# Altium schematic sheet Power_IO.SchDoc(Power_IO)
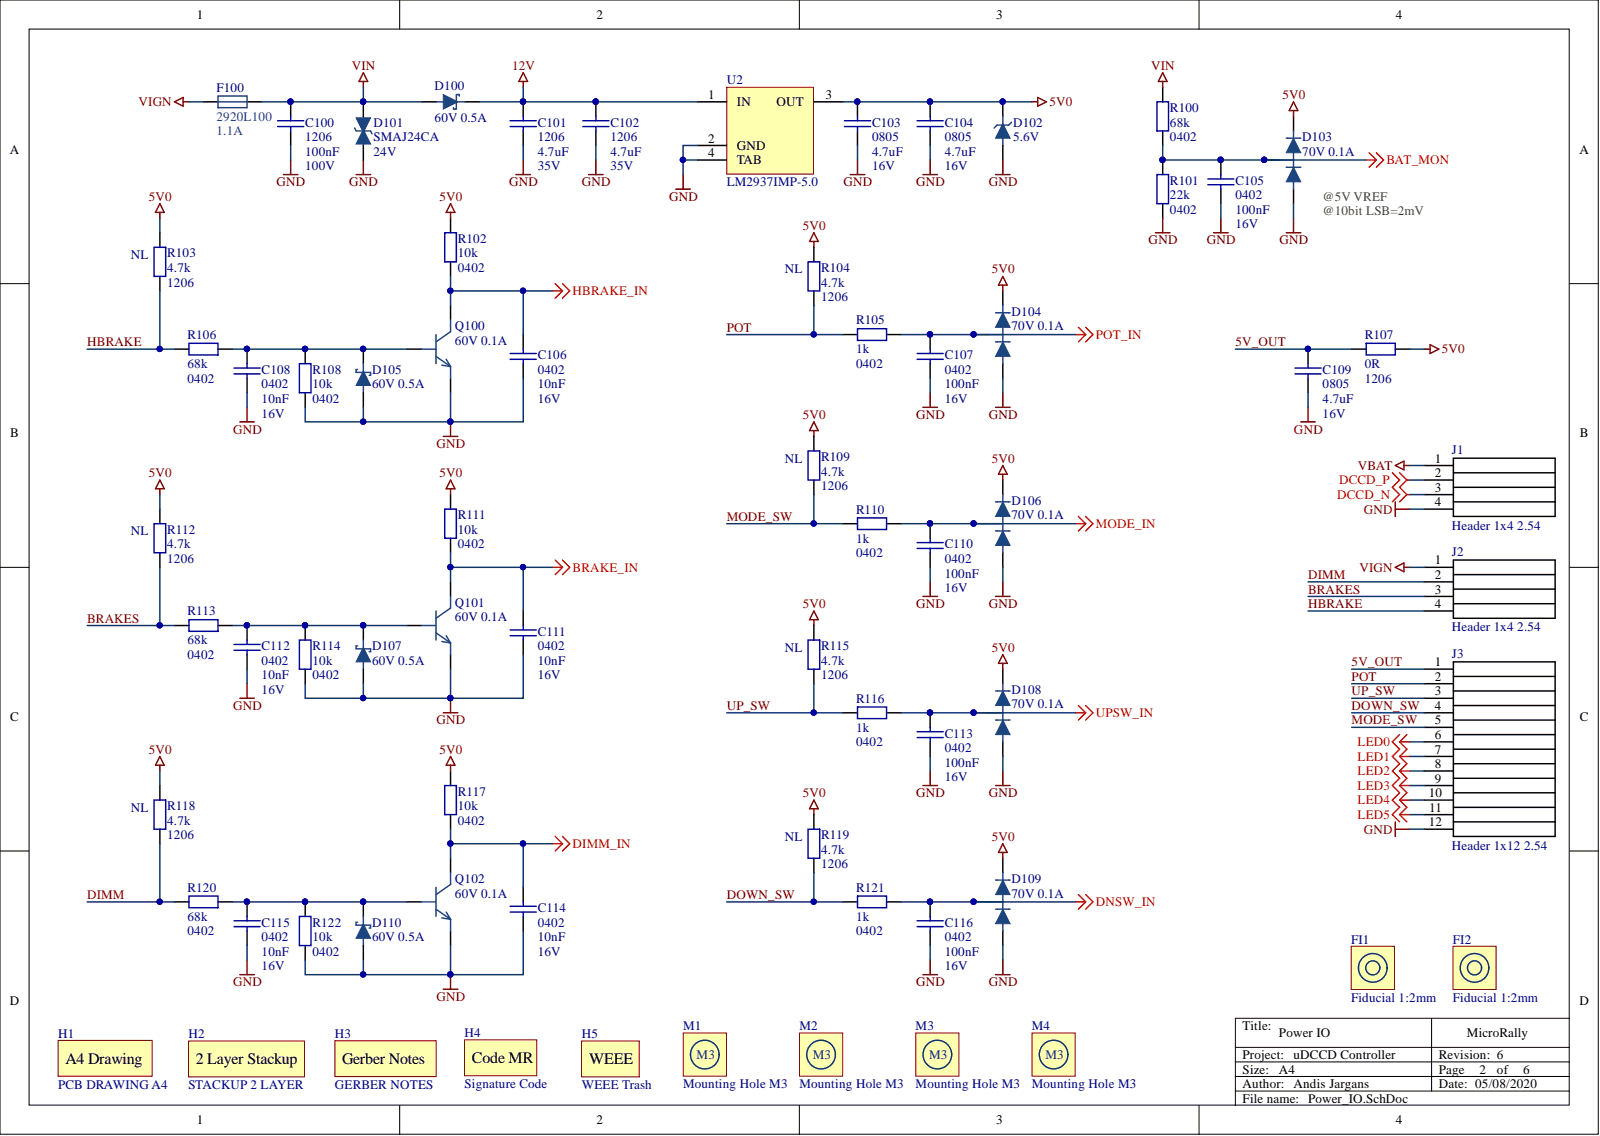
[diagram p0: Power_IO.SchDoc]

## Parts
C100  100n  value 100nF  PACKAGE: 1206  _VOLTAGE: 100V  [C 1206]
C101  4.7u  value 4.7uF  PACKAGE: 1206  _VOLTAGE: 35V  [C 1206]
C102  4.7u  value 4.7uF  PACKAGE: 1206  _VOLTAGE: 35V  [C 1206]
C103  4.7u  value 4.7uF  PACKAGE: 0805  _VOLTAGE: 16V  [C 0805]
C104  4.7u  value 4.7uF  PACKAGE: 0805  _VOLTAGE: 16V  [C 0805]
C105  100n  value 100nF  PACKAGE: 0402  _VOLTAGE: 16V  [C 0402]
C106  10n  value 10nF  PACKAGE: 0402  _VOLTAGE: 16V  [C 0402]
C107  100n  value 100nF  PACKAGE: 0402  _VOLTAGE: 16V  [C 0402]
C108  10n  value 10nF  PACKAGE: 0402  _VOLTAGE: 16V  [C 0402]
C109  4.7u  value 4.7uF  PACKAGE: 0805  _VOLTAGE: 16V  [C 0805]
C110  100n  value 100nF  PACKAGE: 0402  _VOLTAGE: 16V  [C 0402]
C111  10n  value 10nF  PACKAGE: 0402  _VOLTAGE: 16V  [C 0402]
C112  10n  value 10nF  PACKAGE: 0402  _VOLTAGE: 16V  [C 0402]
C113  100n  value 100nF  PACKAGE: 0402  _VOLTAGE: 16V  [C 0402]
C114  10n  value 10nF  PACKAGE: 0402  _VOLTAGE: 16V  [C 0402]
C115  10n  value 10nF  PACKAGE: 0402  _VOLTAGE: 16V  [C 0402]
C116  100n  value 100nF  PACKAGE: 0402  _VOLTAGE: 16V  [C 0402]
D100  value 60V 0.5A  _VOLTAGE: 60V  _IF: 0.5A  [SOD-123]  (hidden comment: STPS0560Z)
D101  SMAJ24CA  value 24V  [DO-214AC]
D102  value 5.6V  [SOT23-3]  (hidden comment: BZX84C5V6)
D103  value 70V 0.1A  _VOLTAGE: 70V  [SOT23-3]  (hidden comment: SBAS70-04LT1G)
D104  value 70V 0.1A  _VOLTAGE: 70V  [SOT23-3]  (hidden comment: SBAS70-04LT1G)
D105  value 60V 0.5A  _VOLTAGE: 60V  [SOD-123]  (hidden comment: STPS0560Z)
D106  value 70V 0.1A  _VOLTAGE: 70V  [SOT23-3]  (hidden comment: SBAS70-04LT1G)
D107  value 60V 0.5A  _VOLTAGE: 60V  [SOD-123]  (hidden comment: STPS0560Z)
D108  value 70V 0.1A  _VOLTAGE: 70V  [SOT23-3]  (hidden comment: SBAS70-04LT1G)
D109  value 70V 0.1A  _VOLTAGE: 70V  [SOT23-3]  (hidden comment: SBAS70-04LT1G)
D110  value 60V 0.5A  _VOLTAGE: 60V  [SOD-123]  (hidden comment: STPS0560Z)
F100  2920L100  value 1.1A  [FL-2920L]
FI1  Fiducial 1:2mm  [FIDUCAIL 1/2]  (no BOM)
FI2  Fiducial 1:2mm  [FIDUCAIL 1/2]  (no BOM)
H1  PCB DRAWING A4  [SHEET A4 DIM]  (no BOM)
H2  STACKUP 2 LAYER  [STACKUP 2 Layer]  (no BOM)
H3  GERBER NOTES  [GERBER NOTES]  (no BOM)
H4  Signature Code  [CODE MR]  (no BOM)
H5  WEEE Trash  [WEEE]  (no BOM)
J1  Header  value Header 1x4 2.54  [HEADER 2.54 1x4 V]
J2  Header  value Header 1x4 2.54  [HEADER 2.54 1x4 V]
J3  Header  value Header 1x12 2.54  [HEADER 2.54 1x12 V]
M1  Mounting Hole M3  [MOUNTING M3]  (no BOM)
M2  Mounting Hole M3  [MOUNTING M3]  (no BOM)
M3  Mounting Hole M3  [MOUNTING M3]  (no BOM)
M4  Mounting Hole M3  [MOUNTING M3]  (no BOM)
Q100  value 60V 0.1A  _Vce: 60V  [SOT23-3]  (hidden comment: MMBT2484)
Q101  value 60V 0.1A  _Vce: 60V  [SOT23-3]  (hidden comment: MMBT2484)
Q102  value 60V 0.1A  _Vce: 60V  [SOT23-3]  (hidden comment: MMBT2484)
R100  68k  PACKAGE: 0402  [R 0402]
R101  22k  PACKAGE: 0402  [R 0402]
R102  10k  PACKAGE: 0402  [R 0402]
R103  4.7k  PLACE: NL  PACKAGE: 1206  [R 1206]
R104  4.7k  PLACE: NL  PACKAGE: 1206  [R 1206]
R105  1k  PACKAGE: 0402  [R 0402]
R106  68k  PACKAGE: 0402  [R 0402]
R107  0R  PACKAGE: 1206  [R 1206]
R108  10k  PACKAGE: 0402  [R 0402]
R109  4.7k  PLACE: NL  PACKAGE: 1206  [R 1206]
R110  1k  PACKAGE: 0402  [R 0402]
R111  10k  PACKAGE: 0402  [R 0402]
R112  4.7k  PLACE: NL  PACKAGE: 1206  [R 1206]
R113  68k  PACKAGE: 0402  [R 0402]
R114  10k  PACKAGE: 0402  [R 0402]
R115  4.7k  PLACE: NL  PACKAGE: 1206  [R 1206]
R116  1k  PACKAGE: 0402  [R 0402]
R117  10k  PACKAGE: 0402  [R 0402]
R118  4.7k  PLACE: NL  PACKAGE: 1206  [R 1206]
R119  4.7k  PLACE: NL  PACKAGE: 1206  [R 1206]
R120  68k  PACKAGE: 0402  [R 0402]
R121  1k  PACKAGE: 0402  [R 0402]
R122  10k  PACKAGE: 0402  [R 0402]
U2  LM2937  value LM2937IMP-5.0  [SOT223-3]

## Nets
5V0: C103.1 C104.1 D102.3 D103.2 D104.2 D106.2 D108.2 D109.2 R102.2 R103.1 R104.1 R107.2 R109.1 R111.2 R112.1 R115.1 R117.2 R118.1 R119.1 U2.3(OUT)
12V: C101.1 C102.1 D100.1 U2.1(IN)
BAT_MON: C105.1 D103.3 R100.1 R101.2
BRAKE_IN: C111.1 Q101.3 R111.1
DCCD_N: J1.3
DCCD_P: J1.2
DIMM_IN: C114.1 Q102.3 R117.1
DNSW_IN: C116.1 D109.3 R121.2
GND: C100.2 C101.2 C102.2 C103.2 C104.2 C105.2 C106.2 C107.2 C108.2 C109.2 C110.2 C111.2 C112.2 C113.2 C114.2 C115.2 C116.2 D101.2 D102.1 D103.1 D104.1 D105.2 D106.1 D107.2 D108.1 D109.1 D110.2 J1.4 J3.12 Q100.2 Q101.2 Q102.2 R101.1 R108.1 R114.1 R122.1 U2.2(GND) U2.4(TAB)
HBRAKE_IN: C106.1 Q100.3 R102.1
LED0: J3.6
LED1: J3.7
LED2: J3.8
LED3: J3.9
LED4: J3.10
LED5: J3.11
MODE_IN: C110.1 D106.3 R110.2
POT_IN: C107.1 D104.3 R105.2
UPSW_IN: C113.1 D108.3 R116.2
VBAT: J1.1
VIGN: F100.1 J2.1
VIN: C100.1 D100.2 D101.1 F100.2 R100.2
5V_OUT: C109.1 J3.1 R107.1
BRAKES: J2.3 R112.2 R113.2
DIMM: J2.2 R118.2 R120.2
DOWN_SW: J3.4 R119.2 R121.1
HBRAKE: J2.4 R103.2 R106.2
MODE_SW: J3.5 R109.2 R110.1
POT: J3.2 R104.2 R105.1
UP_SW: J3.3 R115.2 R116.1
NetC108_1: C108.1 D105.1 Q100.1 R106.1 R108.2
NetC112_1: C112.1 D107.1 Q101.1 R113.1 R114.2
NetC115_1: C115.1 D110.1 Q102.1 R120.1 R122.2

## Library data of the parts
C100 = C_100_100V_1206: Capacitor 1206 100nF 100V; _TOLERANCE: 10%; Library Name: Capacitors Ceramic.IntLib; Component Kind: Standard; _CAPACITANCE: 100nF
C101 C102 = C_4700_35V_1206: Capacitor 1206 4.7uF 35V; _TOLERANCE: 10%; Library Name: Capacitors Ceramic.IntLib; Component Kind: Standard; _CAPACITANCE: 4.7uF
C103 C104 C109 = C_4700_16V_0805: Capacitor 0805 4.7uF 16V; _TOLERANCE: 10%; Library Name: Capacitors Ceramic.IntLib; Component Kind: Standard; _CAPACITANCE: 4.7uF
C105 C107 C110 C113 C116 = C_100_16V_0402: Capacitor 0402 100nF 16V; _TOLERANCE: 10%; Library Name: Capacitors Ceramic.IntLib; Component Kind: Standard; _CAPACITANCE: 100nF
C106 C108 C111 C112 C114 C115 = C_10_16V_0402: Capacitor 0402 10nF 16V; _TOLERANCE: 10%; Library Name: Capacitors Ceramic.IntLib; Component Kind: Standard; _CAPACITANCE: 10nF
D100 = D SC 60V 0.5A SOD123: Schottky Rectifier, 60 V, 0.5 A, Single, SOD-123; PART#: STPS0560Z; PACKAGE: SOD123; Library Name: Diodes.IntLib; Component Kind: Standard
D101 = TVS BD 24V 400W: TVS Bi-Directional Diode 24V 400W DO-214AC; _Vbr_max: 29.5V; _Vbr_,min: 26.7V; _TYPE: OVP; PART#: SMAJ24CA; PACKAGE: DO-214AC; Library Name: Circuit_protection.IntLib; Component Kind: Standard; _Vr: 24V; _POWER: 400W
D102 = D ZE 5.6V SOT-23: Zener Diode, 5.6V @5mA, SOT-23; _POWER: 250mW; PART#: BZX84C5V6; PACKAGE: SOT-23; Library Name: Diodes.IntLib; Component Kind: Standard; _VOLTAGE: 5.6V
D103 D104 D106 D108 D109 = D DS 70V 0.1A SOT23: Dual Series diode SOT23 70V 100mA; _POWER: 225mW; _IF: 0.1A; PART#: SBAS70-04LT1G; PACKAGE: SOT23-3; Library Name: Diodes.IntLib; Component Kind: Standard
D105 D107 D110 = D SC 60V 0.5A SOD123: Schottky Rectifier, 60 V, 0.5 A, Single, SOD-123; _IF: 0.5A; PART#: STPS0560Z; PACKAGE: SOD123; Library Name: Diodes.IntLib; Component Kind: Standard
F100 = PTC 1.1A 33V: Littelfuse PTC SMD Fuse 1.1A 33V 2920L100; _TYPE: OCP; _TRIP_CURRENT: 2.2A; _POWER: 1.5W; PART#: 2920L100; PACKAGE: LF-2920L; Library Name: Circuit_protection.IntLib; Component Kind: Standard; _VOLTAGE: 33V; _HOLD CURRENT: 1.1A
FI1 FI2 = FIDUCIAL 1/2: Round fiducial for Pannels d=1mm, D=2mm; Library Name: PCB_Formating.IntLib
H4 = CODE MR: MicroRally Signature Code; Library Name: PCB_Formating.IntLib
J1 J2 = Header 2.54 1x4 V Male: Pin Header Vertical Male 1x4 pins 2.54mm pitch; _CURRENT: 4A; PACKAGE: 0.1"; Library Name: Connectors.IntLib; Component Kind: Standard
J3 = Header 2.54 1x12 V Male: Pin Header Vertical Male 1x12 pins 2.54mm pitch; _CURRENT: 4A; PACKAGE: 0.1"; Library Name: Connectors.IntLib; Component Kind: Standard
M1-M4 = MH M3: Tooling hole M3, 3.2mm; Library Name: Mechanical.IntLib
Q100-Q102 = Q NPN 60V 0.1A: NPN Transistor 60V 0.1A SOT23; _TYPE: BJT NPN; _POWER: 350mW; _hFE: 100-250; PART#: MMBT2484; PACKAGE: SOT23-3; Library Name: Transistors.IntLib; Component Kind: Standard; _Vcb: 60V; _CURRENT: 0.1A
R100 R106 R113 R120 = R_68000_0402: Resistor 0402 68k 1/16W; _TOLERANCE: 1%; _POWER: 62.5mW; VALUE: 68k; Library Name: Resistors Chip.IntLib; Component Kind: Standard
R101 = R_22000_0402: Resistor 0402 22k 1/16W; _TOLERANCE: 1%; _POWER: 62.5mW; VALUE: 22k; Library Name: Resistors Chip.IntLib; Component Kind: Standard
R102 R108 R111 R114 R117 R122 = R_10000_0402: Resistor 0402 10k 1/16W; _TOLERANCE: 1%; _POWER: 62.5mW; VALUE: 10k; Library Name: Resistors Chip.IntLib; Component Kind: Standard
R103 R104 R109 R112 R115 R118 R119 = R_4700_1206: Resistor 1206 4.7k 1/4W; _TOLERANCE: 1%; _POWER: 250mW; VALUE: 4.7k; Library Name: Resistors Chip.IntLib; Component Kind: Standard
R105 R110 R116 R121 = R_1000_0402: Resistor 0402 1k 1/16W; _TOLERANCE: 1%; _POWER: 62.5mW; VALUE: 1k; Library Name: Resistors Chip.IntLib; Component Kind: Standard
R107 = R_0_1206: Resistor 1206 0 1/4W 1%; _POWER: 250mW; VALUE: 0R; Library Name: Resistors Chip.IntLib; Component Kind: Standard; _TOLERANCE: 1%
U2 = LDO LM2937IMP-5.0: LDO 5.0V 400mA SOT223; PART#: LM2937IMP-5.0/NOPB; _OUTPUT: 5V; _INPUT: 6V..26V; PACKAGE: SOT23-6; Library Name: Power_ICs.IntLib; Component Kind: Standard; _TYPE: LDO; _CURRENT: 400mA
H1 = PCB DRAWING A4: Description: PCB DRAWING A4; Library Name: PCB_Formating.IntLib
H2 = STACKUP 2 LAYER: Description: STACKUP 2 LAYER; Library Name: PCB_Formating.IntLib
H3 = GERBER NOTES: Description: GERBER NOTES; Library Name: PCB_Formating.IntLib
H5 = WEEE Trash: Description: WEEE Trash; Library Name: PCB_Formating.IntLib
